# Altium schematic sheet Thermokoppel_1.SchDoc
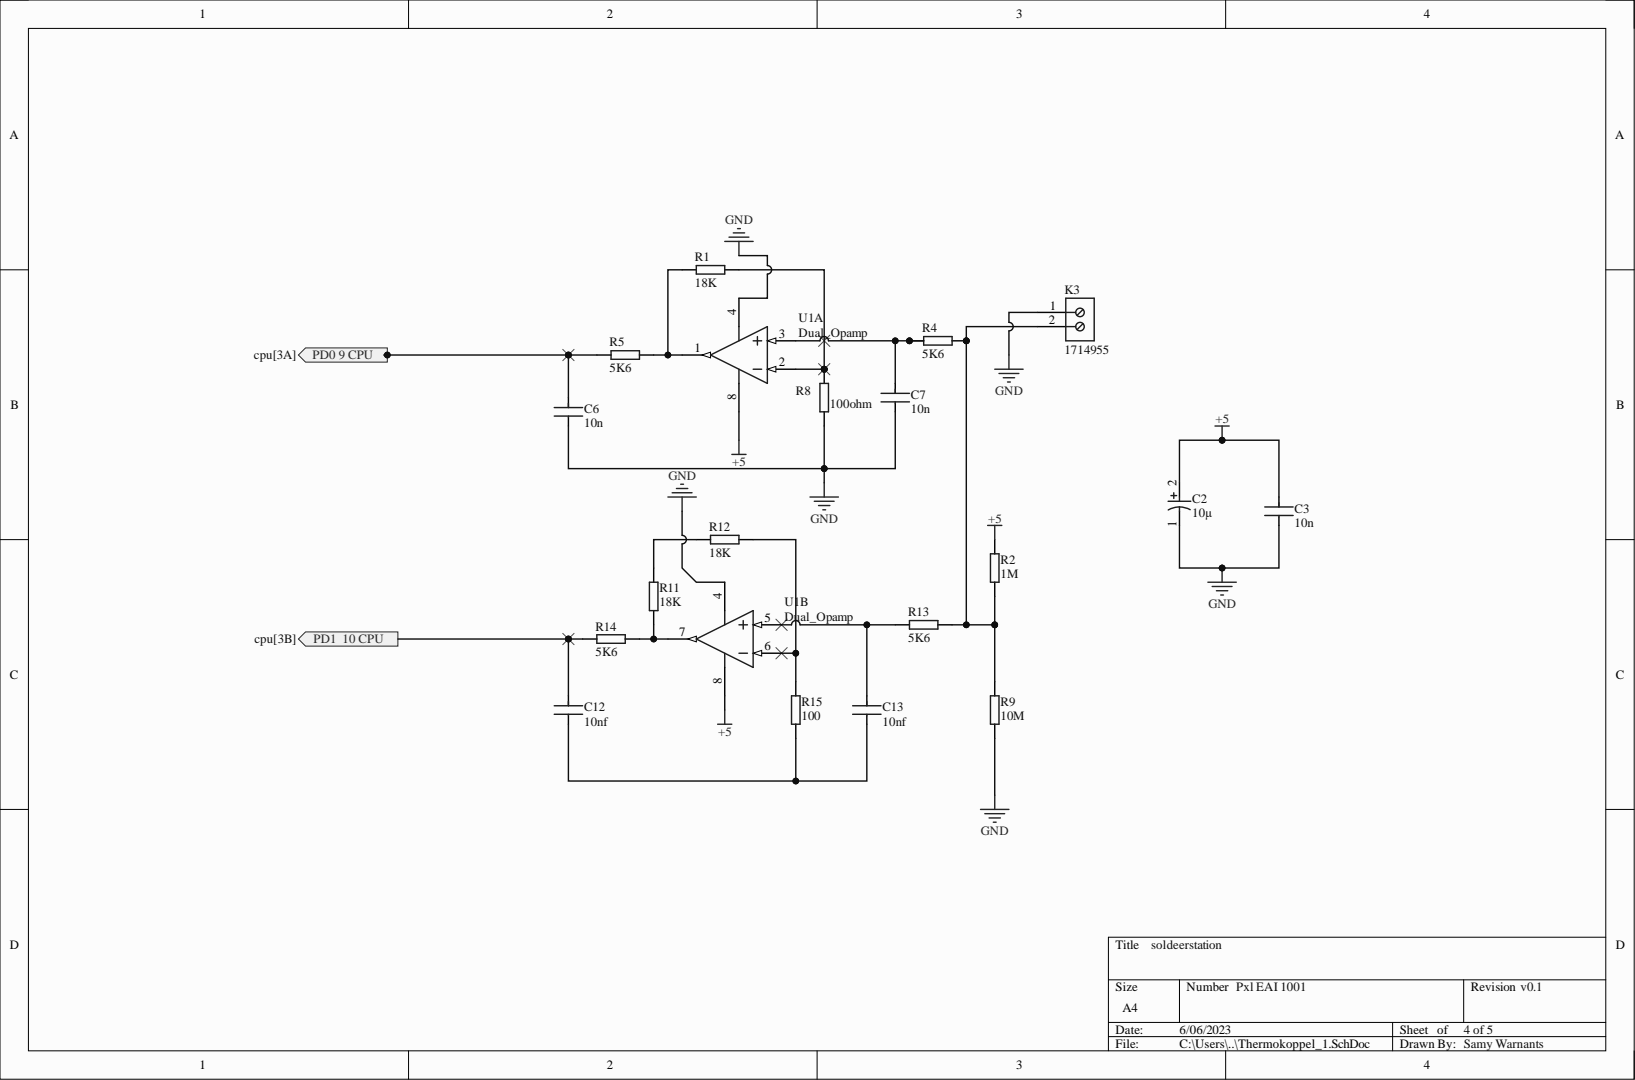
[diagram p0: Thermokoppel_1.SchDoc]

## Parts
C2  10µ  [CE_D6,3_L4,5]
C3  10n  [CC_0805_L]
C6  10n  [CC_0805_L]
C7  10n  [CC_0805_L]
C12  10nf  [CC_0805_L]
C13  10nf  [CC_0805_L]
K3  1714955  [PHOE-1714955_V]
R1  18K  [R_0805_M]
R2  1M  [R_0805_M]
R4  5K6  [R_0805_M]
R5  5K6  [R_0805_M]
R8  100ohm  [R_0805_M]
R9  10M  [R_0805_M]
R11  18K  [R_0805_M]
R12  18K  [R_0805_M]
R13  5K6  [R_0805_M]
R14  5K6  [R_0805_M]
R15  100  [R_0805_M]
U1  Dual_Opamp  [MSOP_8_M]  (parts A,B)

## Ports
PD0 9 CPU, PD1  10 CPU

## Nets
+5: C2.2 C3.1 R2.2 U1.8
GND: C2.1 C3.2 C6.2 C7.2 K3.1 R8.1 R9.1 U1.4
PD0 9 CPU: C6.1 R5.1 ; port PD0 9 CPU
PD1  10 CPU: C12.1 R14.1 ; port PD1  10 CPU
NetC7_1: C7.1 R4.1 U1.3
NetC12_2: C12.2 C13.2 R15.1
NetC13_1: C13.1 R13.1 U1.5
NetK3_2: K3.2 R2.1 R4.2 R9.2 R13.2
NetR1_1: R1.1 R5.2 U1.1
NetR1_2: R1.2 R8.2 U1.2
NetR11_1: R11.1 R14.2 U1.7
NetR11_2: R11.2 R12.1
NetR12_2: R12.2 R15.2 U1.6

## Library data of the parts
C2 = Capacitor_Electrolytic: Manufacturer: Panasonic; Voltage Rating: 25 V; Component Kind: Standard; ComponentLink1Description: Manufacturer URL; ComponentLink1URL: http://www.panasonic.com/; ComponentLink2Description: Datasheet; ComponentLink2URL: http://industrial.panasonic.com/cdbs/www-data/pdf/RDE0000/ABA0000C1181.pdf; DatasheetVersion: 03/2016; Height: 10.2 mm; Lead Pitch: 3.1 mm; Length: 10.2 mm; Max Operating Temperature: 105 degC; Min Operating Temperature: -55 degC; Mount: Surface Mount; Mounting Technology: Surface Mount; Package Quantity: 500; PackageDescription: 2-Pin Electrolytic Aluminum Capacitor, Body 8.3 x 8.3 mm; PackageReference: EEE-FK1E221P; Packaging: Tape and Reel; Pins: 2; REACH SVHC: No SVHC; RoHSCompliant: true; Library Name: Soldeerstation_Final (1).SchLib
C3 C6 C7 C12 C13 = Capacitor_Ceramic: Manufacturer: KEMET; Manufacturer Part Number: C0805C224K5RACTU; Capacitance: 0.22 μF; Temperature Characteristic: X7R; Temperature Coefficient: 30ppm/°C; Tolerance: 0.1; Value: 0.22 μF; Voltage Rating: 50 V; Automotive: No; Category: Cap; Component Kind: Standard; ComponentLink2Description: Datasheet; ComponentLink2URL: https://content.kemet.com/datasheets/KEM_C1003_C0G_SMD.pdf; Device Class L1: Passive Components; Device Class L2: Capacitors; Device Class L3: Ceramic Capacitors; Digikey Description: CAP CER 0.22UF 50V X7R 0805; Height: 0.88mm; Ipc Land Pattern Name: CAPC200125X78; Lead Free: Yes; Library Name: Schlib1.SchLib; Material: Ceramic; Mouser Description: CAP CER 0.22UF 50V X7R 0805; Octopart: https://octopart.com/c0805c224k5ractu-kemet-144670; Package: C0805C-DN; RoHS: TRUE; Temperature: -55°C to +125°C; Temperature Range High: +125°C; Temperature Range Low: -55°C
K3 = CMP-2000-05797-1: PC Terminal Block, Pitch 6.35 mm, 1 x 2 Position, Height 21.5 mm, Tail Lenght 5.1 mm, RoHS, Bulk; Manufacturer: Phoenix Contact; Component Kind: Standard; Datasheet URL: http://media.digikey.com/pdf/Data%20Sheets/Phoenix%20Contact%20PDFs/1714955.pdf; Datasheet Version: 02/2010; Library Name: Altium Content Vault; Manufacturer URL: http://www.phoenixcontact.com/; Mounting Technology: ThroughHole; Package Description: PC Terminal Block, Pitch 6.35 mm, 1 x 2 Position, Height 21.5 mm, Tail Lenght 5.1 mm; Package Reference: 1714955
R1 R2 R4 R5 R8 R9 R11-R15 = Resistor: Manufacturer: Vishay Dale; Part Number: CRCW08050000Z0EA; Component Kind: Standard; Library Name: Schlib1.SchLib
U1 = Dual_Opamp: Amplifier Type: GENERAL PURPOSE; Bandwidth: 1MHz; Common Mode Rejection Ratio: 60dB; Input Bias Current: 1pA; Input Offset Voltage (Vos): 4.5mV; Max Supply Voltage: 6V; Min Supply Voltage: 1.8V; Nominal Supply Current: 100uA; Number of Amplifiers: 2; Operating Supply Voltage: 5.5V; Output Current: 23mA; Power Supply Rejection Ratio (PSRR): 86dB; Slew Rate: 0.6V/µs; Voltage Gain: 112dB; Case/Package: PDIP; Component Kind: Standard; Gain Bandwidth Product: 1MHz; Height: 3.3mm; Input Offset Voltage-Max: 4.5mV; Lead Free: Lead Free; Length: 9.27mm; Max Operating Temperature: 125°C; Min Operating Temperature: -40°C; Mount: Through Hole; Number of Channels: 2; Number of Circuits: 2; Number of Elements: 2; Number of Pins: 8; Operating Supply Current: 100uA; Output Current per Channel: 23mA; Package Quantity: 60; Packaging: Rail/Tube; Radiation Hardening: No; REACH SVHC: No SVHC; RoHS Compliant: Yes; Slew Rate-Nom: 0.6V/µs; Supply Current: 100uA; Technology: CMOS; Unity Gain Bandwidth Product: 1MHz; Width: 6.35mm; Library Name: Soldeerstation_Final (1).SchLib
